# Altium schematic sheet Main.SchDoc
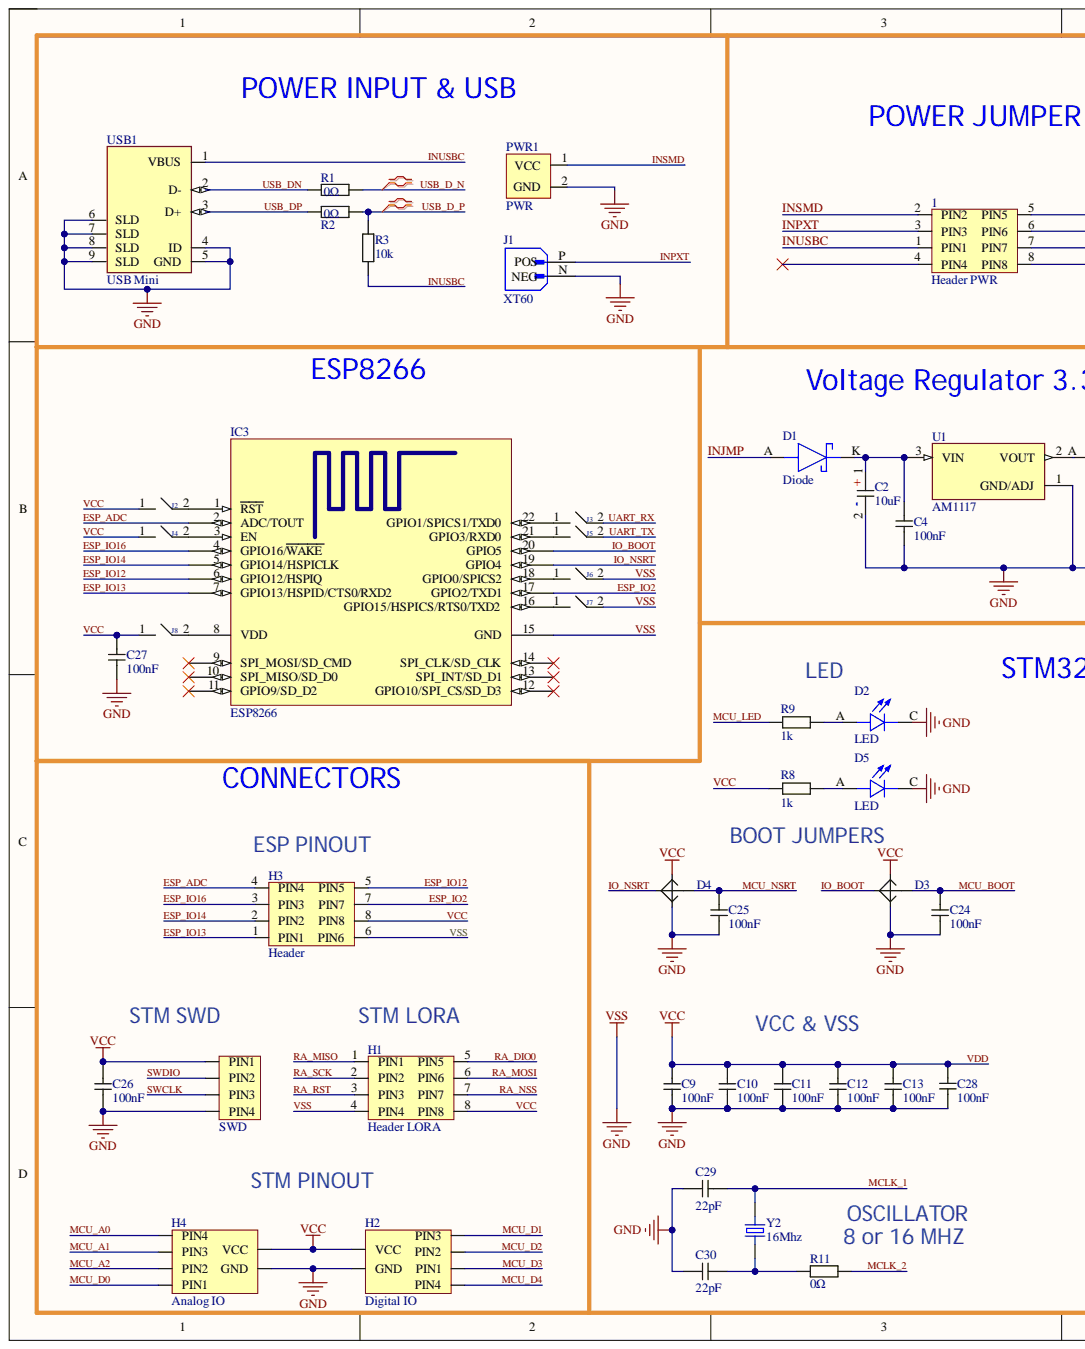
[diagram p0: Main.SchDoc, left]
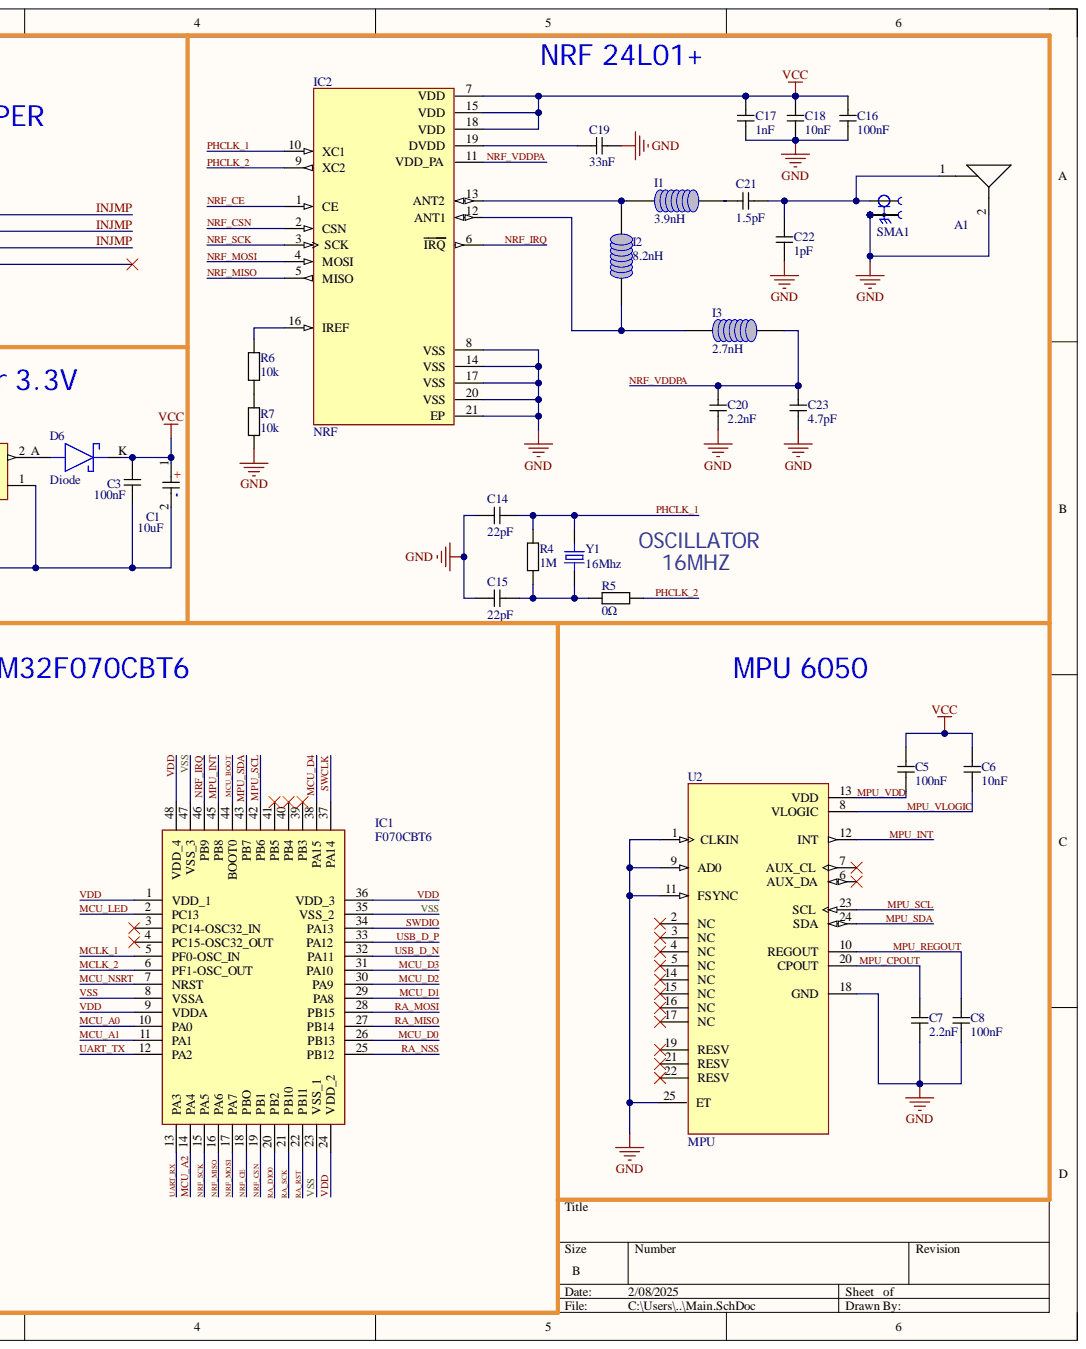
[diagram p1: Main.SchDoc, right]

## Parts
1  Header PWR  [CONN_header4x2@PFE]
A1  ANT  [CONN_PCBAntenna@PFE]  (value not drawn)
C1  10uF  [CAPA_10uF@PFE]
C2  10uF  [CAPA_10uF@PFE]
C3  100nF  [CAPA_100nF@PFE]
C4  100nF  [CAPA_100nF@PFE]
C5  100nF  [CAPA_100nF@PFE]
C6  10nF  [CAPA_2mm@PFE]
C7  2.2nF  [CAPA_2mm@PFE]
C8  100nF  [CAPA_100nF@PFE]
C9  100nF  [CAPA_100nF@PFE]
C10  100nF  [CAPA_100nF@PFE]
C11  100nF  [CAPA_100nF@PFE]
C12  100nF  [CAPA_100nF@PFE]
C13  100nF  [CAPA_100nF@PFE]
C14  22pF  [CAPA_2mm@PFE]
C15  22pF  [CAPA_2mm@PFE]
C16  100nF  [CAPA_100nF@PFE]
C17  1nF  [CAPA_2mm@PFE]
C18  10nF  [CAPA_2mm@PFE]
C19  33nF  [CAPA_2mm@PFE]
C20  2.2nF  [CAPA_100nF@PFE]
C21  1.5pF  [CAPA_2mm@PFE]
C22  1pF  [CAPA_2mm@PFE]
C23  4.7pF  [CAPA_100nF@PFE]
C24  100nF  [CAPA_100nF@PFE]
C25  100nF  [CAPA_100nF@PFE]
C26  100nF  [CAPA_100nF@PFE]
C27  100nF  [CAPA_100nF@PFE]
C28  100nF  [CAPA_100nF@PFE]
C29  22pF  [CAPA_2mm@PFE]
C30  22pF  [CAPA_2mm@PFE]
D1  Diode  [DIODE_B310@PFE]
D2  LED  [LED_green@PFE]
D3  JMP  [CONN_DEBUG2@PFE]  (value not drawn)
D4  JMP  [CONN_DEBUG2@PFE]  (value not drawn)
D5  LED  [LED_green@PFE]
D6  Diode  [DIODE_B310@PFE]
H1  Header LORA  [CONN_header4x2@PFE]
H2  Digital IO  [CONN_header4x3@PFE]
H3  Header  [CONN_header4x2@PFE]
H4  Analog IO  [CONN_header4x3@PFE]
I1  3.9nH  [INDUC_NRF@PFE]
I2  8.2nH  [INDUC_NRF@PFE]
I3  2.7nH  [INDUC_NRF@PFE]
IC1  F070CBT6  [IC_STM32F070CBT6@PFE]
IC2  NRF  [IC_NRF24L01@PFE]
IC3  ESP8266  [IC_ESP8266mod@PFE]
J1  XT60  [AMASS_XT60-M]
J2  CONN_header1x2@PFE  [CONN_header1x2@PFE]  (value not drawn)
J3  CONN_header1x2@PFE  [CONN_header1x2@PFE]  (value not drawn)
J4  CONN_header1x2@PFE  [CONN_header1x2@PFE]  (value not drawn)
J5  CONN_header1x2@PFE  [CONN_header1x2@PFE]  (value not drawn)
J6  CONN_header1x2@PFE  [CONN_header1x2@PFE]  (value not drawn)
J7  CONN_header1x2@PFE  [CONN_header1x2@PFE]  (value not drawn)
J8  CONN_header1x2@PFE  [CONN_header1x2@PFE]  (value not drawn)
PWR1  PWR  [CONN_pwr@PFE]
R1  0Ω  [RES_0ohm@PFE]
R2  0Ω  [RES_0ohm@PFE]
R3  10k  [RES_10k@PFE]
R4  1M  [RES_2mm@PFE]
R5  0Ω  [RES_0ohm@PFE]
R6  10k  [RES_10k@PFE]
R7  10k  [RES_10k@PFE]
R8  1k  [RES_2mm@PFE]
R9  1k  [RES_2mm@PFE]
R11  0Ω  [RES_0ohm@PFE]
SMA1  SMA  [CONN_samF@PFE]
SWD  CONN_header4x1@PFE  [CONN_header4x1@PFE]  (value not drawn)
U1  AM1117  [REGU_AM1117@PFE]
U2  MPU  [IC_MPU6050@PFE]
USB1  USB Mini  [CONN_USB_mini1@PFE]
Y1  16Mhz  [OSCI_2PIN@PFE]
Y2  16Mhz  [OSCI_2PIN@PFE]

## Nets
ESP_ADC: H3.4(PIN4) IC3.2(ADC/TOUT)
ESP_IO2: H3.7(PIN7) IC3.17(GPIO2/TXD1)
ESP_IO12: H3.5(PIN5) IC3.6(GPIO12/HSPIQ)
ESP_IO13: H3.1(PIN1) IC3.7(GPIO13/HSPID/CTS0/RXD2)
ESP_IO14: H3.2(PIN2) IC3.5(GPIO14/HSPICLK)
ESP_IO16: H3.3(PIN3) IC3.4(GPIO16/WAKE)
INJMP: 1.5(PIN5) 1.6(PIN6) 1.7(PIN7) D1.A
INPXT: 1.3(PIN3) J1.P
INSMD: 1.2(PIN2) PWR1.1
INUSBC: 1.1(PIN1) R3.1 USB1.1(VBUS)
IO_BOOT: D3.3 IC3.20(GPIO5)
IO_NSRT: D4.3 IC3.19(GPIO4)
MCLK_1: C29.2 IC1.5(PF0-OSC_IN) Y2.2
MCLK_2: IC1.6(PF1-OSC_OUT) R11.2
MCU_A0: H4.4(PIN4) IC1.10(PA0)
MCU_A1: H4.3(PIN3) IC1.11(PA1)
MCU_A2: H4.2(PIN2) IC1.14(PA4)
MCU_BOOT: C24.2 D3.1 IC1.44(BOOT0)
MCU_D0: H4.1(PIN1) IC1.26(PB13)
MCU_D1: H2.3(PIN3) IC1.29(PA8)
MCU_D2: H2.2(PIN2) IC1.30(PA9)
MCU_D3: H2.1(PIN1) IC1.31(PA10)
MCU_D4: H2.4(PIN4) IC1.38(PA15)
MCU_LED: IC1.2(PC13) R9.2
MCU_NSRT: C25.2 D4.1 IC1.7(NRST)
MPU_CPOUT: C7.2 U2.20(CPOUT)
MPU_INT: IC1.45(PB8) U2.12(INT)
MPU_REGOUT: C8.2 U2.10(REGOUT)
MPU_SCL: IC1.42(PB6) U2.23(SCL)
MPU_SDA: IC1.43(PB7) U2.24(SDA)
MPU_VDD: C5.1 U2.13(VDD)
MPU_VLOGIC: C6.1 U2.8(VLOGIC)
NRF_CE: IC1.18(PBO) IC2.1(CE)
NRF_CSN: IC1.19(PB1) IC2.2(CSN)
NRF_IRQ: IC1.46(PB9) IC2.6(IRQ)
NRF_MISO: IC1.16(PA6) IC2.5(MISO)
NRF_MOSI: IC1.17(PA7) IC2.4(MOSI)
NRF_SCK: IC1.15(PA5) IC2.3(SCK)
NRF_VDDPA: C20.2 C23.2 I3.2 IC2.11(VDD_PA)
PHCLK_1: C14.2 IC2.10(XC1) R4.2 Y1.2
PHCLK_2: IC2.9(XC2) R5.2
RA_DIO0: H1.5(PIN5) IC1.20(PB2)
RA_MISO: H1.1(PIN1) IC1.27(PB14)
RA_MOSI: H1.6(PIN6) IC1.28(PB15)
RA_NSS: H1.7(PIN7) IC1.25(PB12)
RA_RST: H1.3(PIN3) IC1.22(PB11)
RA_SCK: H1.2(PIN2) IC1.21(PB10)
SWCLK: IC1.37(PA14) SWD.3(PIN3)
SWDIO: IC1.34(PA13) SWD.2(PIN2)
UART_RX: IC1.13(PA3) J3.2
UART_TX: IC1.12(PA2) J5.2
USB_D_N: IC1.32(PA11) R1.2
USB_D_P: IC1.33(PA12) R2.2 R3.2
USB_DN: R1.1 USB1.2(D-)
USB_DP: R2.1 USB1.3(D+)
VCC: C1.1 C3.1 C5.2 C6.2 C9.2 C10.2 C11.2 C12.2 C13.2 C16.2 C17.2 C18.2 C26.2 C27.2 C28.2 D3.2 D4.2 D6.K H1.8(PIN8) H2.5(VCC) H3.8(PIN8) H4.5(VCC) IC1.1(VDD_1) IC1.9(VDDA) IC1.24(VDD_2) IC1.36(VDD_3) IC1.48(VDD_4) IC2.7(VDD) IC2.15(VDD) IC2.18(VDD) J2.1 J4.1 J8.1 R8.2 SWD.1(PIN1)
VSS: A1.2 C1.2 C2.2 C3.2 C4.2 C7.1 C8.1 C9.1 C10.1 C11.1 C12.1 C13.1 C14.1 C15.1 C16.1 C17.1 C18.1 C19.1 C20.1 C22.1 C23.1 C24.1 C25.1 C26.1 C27.1 C28.1 C29.1 C30.1 D2.C D3.4 D4.4 D5.C H1.4(PIN4) H2.6(GND) H3.6(PIN6) H4.6(GND) IC1.8(VSSA) IC1.23(VSS_1) IC1.35(VSS_2) IC1.47(VSS_3) IC2.8(VSS) IC2.14(VSS) IC2.17(VSS) IC2.20(VSS) IC2.21(EP) IC3.15(GND) J1.N J6.2 J7.2 PWR1.2 R7.1 SMA1.G1 SMA1.G2 SMA1.G3 SMA1.G4 SWD.4(PIN4) U1.1 U2.1(CLKIN) U2.9(AD0) U2.11(FSYNC) U2.18(GND) U2.25(ET) USB1.4(ID) USB1.5(GND) USB1.6(SLD) USB1.7(SLD) USB1.8(SLD) USB1.9(SLD)
NetA1_1: A1.1 C21.2 C22.2 SMA1.1
NetC2_1: C2.1 C4.1 D1.K U1.3
NetC15_2: C15.2 R4.1 R5.1 Y1.1
NetC19_2: C19.2 IC2.19(DVDD)
NetC21_1: C21.1 I1.2
NetC30_2: C30.2 R11.1 Y2.1
NetD2_A: D2.A R9.1
NetD5_A: D5.A R8.1
NetD6_A: D6.A U1.2
NetI1_1: I1.1 I2.2 IC2.13(ANT2)
NetI2_1: I2.1 I3.1 IC2.12(ANT1)
NetIC2_16: IC2.16(IREF) R6.2
NetIC3_1: IC3.1(RST) J2.2
NetIC3_3: IC3.3(EN) J4.2
NetIC3_8: IC3.8(VDD) J8.2
NetIC3_16: IC3.16(GPIO15/HSPICS/RTS0/TXD2) J7.1
NetIC3_18: IC3.18(GPIO0/SPICS2) J6.1
NetIC3_21: IC3.21(GPIO3/RXD0) J5.1
NetIC3_22: IC3.22(GPIO1/SPICS1/TXD0) J3.1
NetR6_1: R6.1 R7.2

Not connected: 1 4(PIN4) 8(PIN8); IC1 3(PC14-OSC32_IN) 4(PC15-OSC32_OUT) 39(PB3) 40(PB4) 41(PB5); IC3 9(SPI_MOSI/SD_CMD) 10(SPI_MISO/SD_D0) 11(GPIO9/SD_D2) 12(GPIO10/SPI_CS/SD_D3) 13(SPI_INT/SD_D1) 14(SPI_CLK/SD_CLK); U2 2(NC) 3(NC) 4(NC) 5(NC) 6(AUX_DA) 7(AUX_CL) 14(NC) 15(NC) 16(NC) 17(NC) 19(RESV) 21(RESV) 22(RESV)

## Library data of the parts
1 H1 H3 = CONN_header4x2@PFE: Arduino 4x2 Header pin; Component Kind: Standard; Library Name: Amalan.IntLib
A1 = CONN_PCBANTENNA@PFE: Component Kind: Standard; Library Name: Amalan.IntLib
C1 C2 = CAPA_10uF@PFE: 10uF polarise noir; Component Kind: Standard; Library Name: Amalan.IntLib
C3-C5 C8-C13 C16 C20 C23-C28 = CAPA_100nF@PFE: 100nF big capa; Component Kind: Standard; Library Name: Amalan.IntLib
C6 C7 C14 C15 C17-C19 C21 C22 C29 C30 = CAPA_2mm@PFE: 2mm/1mm capa; Component Kind: Standard; Library Name: Amalan.IntLib
D1 D6 = DIODE_B10@PFE: Diode 100v 3A; Manufacturer_Name: onsemi; Manufacturer_Part_Number: MBRS3100T3G; Arrow Part Number: MBRS3100T3G; Arrow Price/Stock: https://www.arrow.com/en/products/mbrs3100t3g/on-semiconductor?utm_currency=USD&region=nac; Component Kind: Standard; Datasheet Link: http://www.onsemi.com/pub/Collateral/MBRS3100T3-D.PDF; Height: 2.61mm; Mouser Part Number: 863-MBRS3100T3G; Mouser Price/Stock: https://www.mouser.co.uk/ProductDetail/onsemi/MBRS3100T3G?qs=3JMERSakebrbkNtjl3tdRw%3D%3D; Library Name: Amalan.IntLib
D2 D5 = LED_green@PFE: Green 571nm LED Indication - Discrete 2V 1206 (3216 Metric); MF: Lite-On Inc.; MP: LTST-C230KGKT; Availability: In Stock; Check_prices: https://www.snapeda.com/parts/LTST-C230KGKT/Lite-On+Inc./view-part/?ref=eda; Component Kind: Standard; Package: 1206 Lite-On; Price: None; Purchase-URL: https://pricing.snapeda.com/search?q=LTST-C230KGKT&ref=eda; SnapEDA_Link: https://www.snapeda.com/parts/LTST-C230KGKT/Lite-On+Inc./view-part/?ref=snap; Library Name: Amalan.IntLib
D3 D4 = CONN_DEBUG2@PFE: just Debug; Component Kind: Standard; Library Name: Amalan.IntLib
H2 H4 = CONN_header4x3@PFE: VCC,GND,Signal-Header; Component Kind: Standard; Library Name: Amalan.IntLib
I1-I3 = INDUC_NRF@PFE: Component Kind: Standard; Library Name: Amalan.IntLib
IC1 = IC_STM32F070CBT6@PFE: Integrated Circuit; Manufacturer_Name: STMicroelectronics; Arrow Part Number: STM32F070CBT6; Arrow Price/Stock: https://www.arrow.com/en/products/stm32f070cbt6/stmicroelectronics?region=nac; Component Kind: Standard; Datasheet Link: http://www.st.com/content/ccc/resource/technical/document/datasheet/31/4f/10/5f/a5/32/46/42/DM00141386.pdf/files/DM00141386.pdf/jcr:content/translations/en.DM00141386.pdf; Height: 1.6mm; Manufacturer_Part_Number: STM32F070CBT6; Mouser Part Number: 511-STM32F070CBT6; Mouser Price/Stock: https://www.mouser.co.uk/ProductDetail/STMicroelectronics/STM32F070CBT6?qs=ClYTdQWm4hD002rCRCmgew%3D%3D; Library Name: Amalan.IntLib
IC2 = IC_NRF24L01@PFE: IC RF TxRx Only General ISM > 1GHZ 2.4GHz 20-VFQFN Exposed Pad; MF: Nordic Semiconductor; MP: NRF24L01+; Availability: Unavailable; Component Kind: Standard; Package: QFN-20 Nordic Semiconductor; Price: None; SnapEDA_Link: https://www.snapeda.com/parts/NRF24L01%2B/Nordic%20Semiconductor%20ASA/view-part/1023904/?ref=snap; Library Name: Amalan.IntLib
IC3 = IC_ESP8266mod@PFE: ESP8266 ESP-12-E; Component Kind: Standard; Library Name: Amalan.IntLib
J1 = XT60-M: Plug; DC supply; XT60; male; PIN: 2; for cable; soldered; 30A; 500V; MF: AMASS; Check_prices: https://www.snapeda.com/parts/XT60-M/AMASS/view-part/?ref=eda; Component Kind: Standard; Library Name: XT60-M.SchLib; MP: XT60-M; Package: Package; SnapEDA_Link: https://www.snapeda.com/parts/XT60-M/AMASS/view-part/?ref=snap
PWR1 = CONN_pwr@PFE: On Board PWR; Component Kind: Standard; Library Name: Amalan.IntLib
R1 R2 R5 R11 = RES_0ohm@PFE: RES 0 ohm; Component Kind: Standard; Library Name: Amalan.IntLib
R3 R6 R7 = RES_10k@PFE: SMD RES 10k; Component Kind: Standard; Library Name: Amalan.IntLib
R4 R8 R9 = RES_2mm@PFE: 2mm/1mm RES; Component Kind: Standard; Library Name: Amalan.IntLib
SMA1 = CONN_smaF@PFE: Straight SMA Connector; MP: altium_native_batch; Availability: Unavailable; Component Kind: Standard; Package: None; Price: None; Library Name: Amalan.IntLib
SWD = CONN_header4x1@PFE: 1x4 Header; Component Kind: Standard; Library Name: Amalan.IntLib
U1 = REGU_AMS1117@PFE: Sot223/Pkg 1-Amp 3.3-Volt Low Drop Out Voltage Regulatator; MF: Advanced Monolithic Systems; MP: AMS1117-3.3; Availability: Not in stock; Check_prices: https://www.snapeda.com/parts/AMS1117-3.3/Advanced+Monolithic+Systems/view-part/?ref=eda; Component Kind: Standard; Package: SOT-223 Seeed Technology; Price: None; SnapEDA_Link: https://www.snapeda.com/parts/AMS1117-3.3/Advanced+Monolithic+Systems/view-part/?ref=snap; Library Name: Amalan.IntLib
U2 = IC_MPU6050@PFE: MPU-6050 series Accelerometer, Gyroscope, 3 Axis Sensor Evaluation Board; MF: TDK InvenSense; Check_prices: https://www.snapeda.com/parts/MPU-6050/TDK+InvenSense/view-part/?ref=eda; Component Kind: Standard; MP: MPU-6050; Package: QFN-24 InvenSense; SnapEDA_Link: https://www.snapeda.com/parts/MPU-6050/TDK+InvenSense/view-part/?ref=snap; Library Name: Amalan.IntLib
USB1 = CONN_USB_mini1@PFE: Conn Mini USB 2.0 Type B RCP 5 POS 0.8mm Solder RA SMD 5 Terminal 1 Port Box/Tray; Centerline_Pitch: .8 mm[.031 in]; MF: TE Connectivity; MP: 1734035-1; Number_of_Positions: 5; Product_Type: Connector; Availability: Unavailable; Component Kind: Standard; EU_RoHS_Compliance: Compliant; Package: None; Price: None; SnapEDA_Link: https://www.snapeda.com/parts/1734035-1/TE%20Connectivity%20AMP%20Connectors/view-part/494426/?ref=snap; TE_purchase_URL: https://www.te.com/usa-en/product-1734035-1.html?te_bu=Cor&te_type=disp&te_campaign=seda_glo_cor-seda-global-disp-prtnr-fy19-seda-model-bom-cta_sma-317_1&elqCampaignId=32493; Library Name: Amalan.IntLib
Y1 Y2 = OSCI_2pin@PFE: 16.000312MHz Â±30ppm Crystal 18pF 40 Ohms HC-49/US; MF: ECS International; MP: ECS-160.003-18-4; Availability: Warning; Check_prices: https://www.snapeda.com/parts/ECS-160.003-18-4/ECS%20International/view-part/2547681/?ref=eda; Component Kind: Standard; Package: HC-49/US ECS International; Price: None; Purchase-URL: https://pricing.snapeda.com/search/part/ECS-160.003-18-4/?ref=eda; SnapEDA_Link: https://www.snapeda.com/parts/ECS-160.003-18-4/ECS%20International/view-part/2547681/?ref=snap; Library Name: Amalan.IntLib
J2-J8 = CONN_header1x2@PFE: Component Kind: Standard; Library Name: Amalan.IntLib
